# Altium schematic sheet 2407_Alimentation.SchDoc
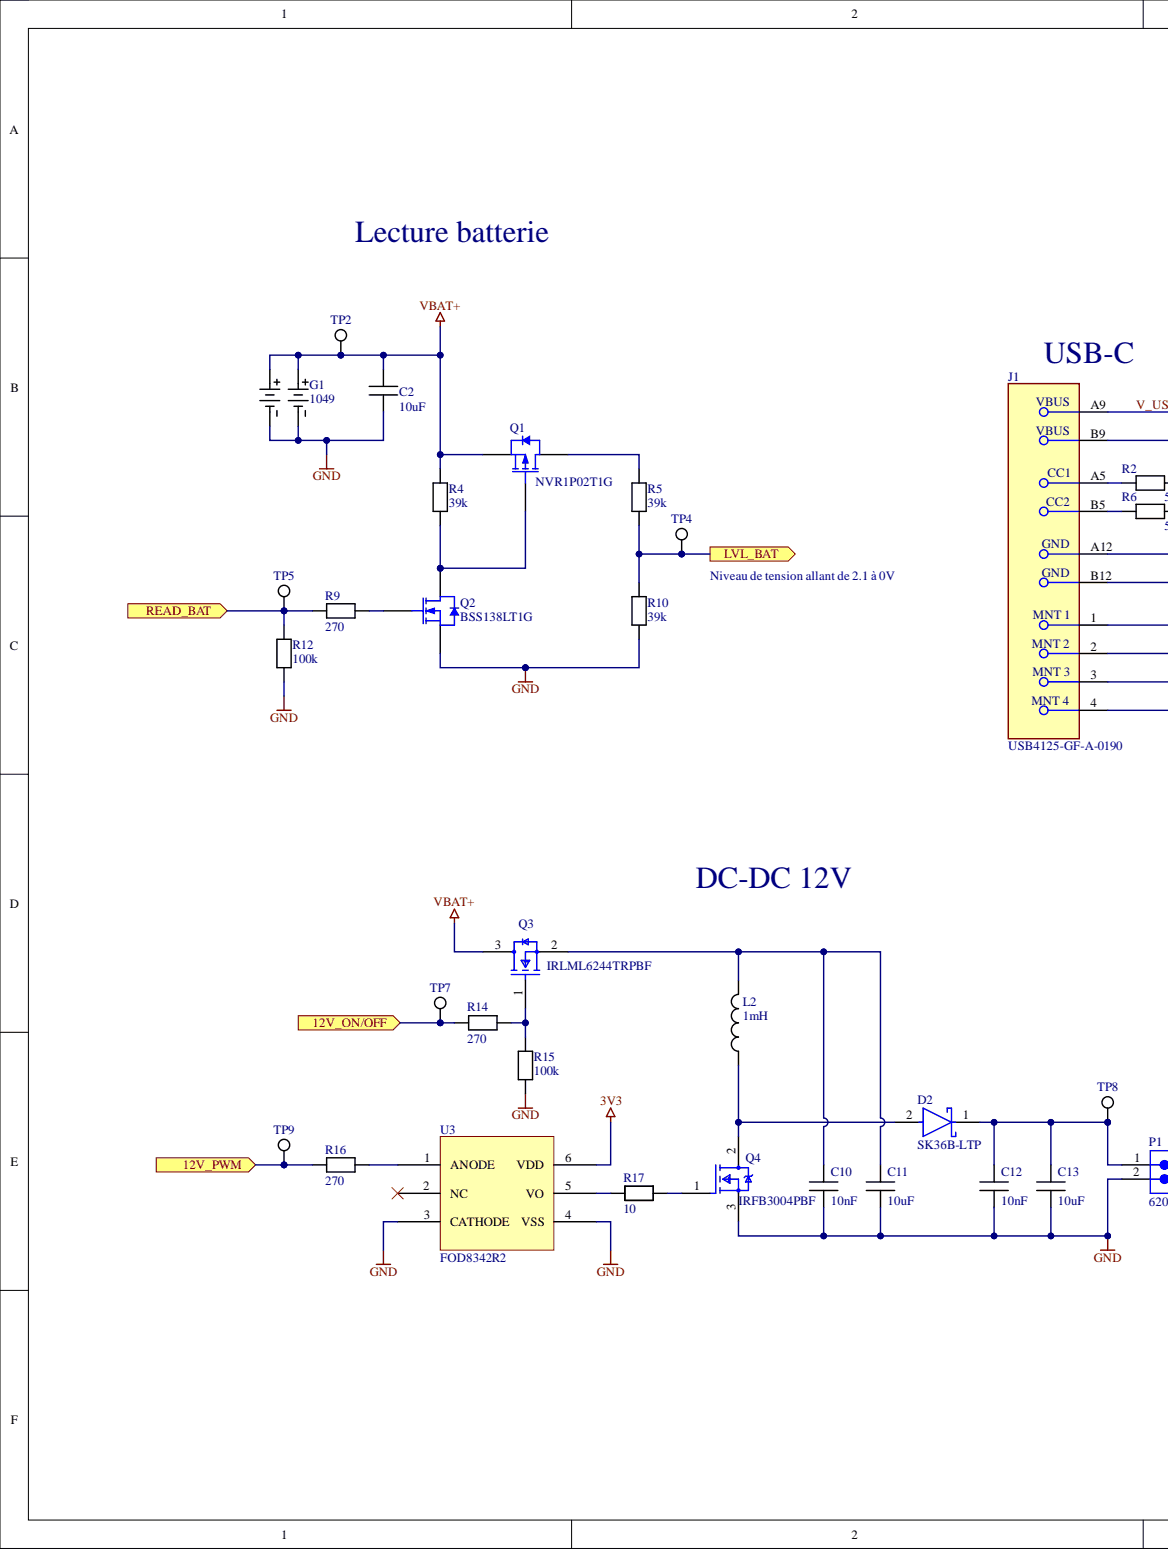
[diagram p0: 2407_Alimentation.SchDoc, left]
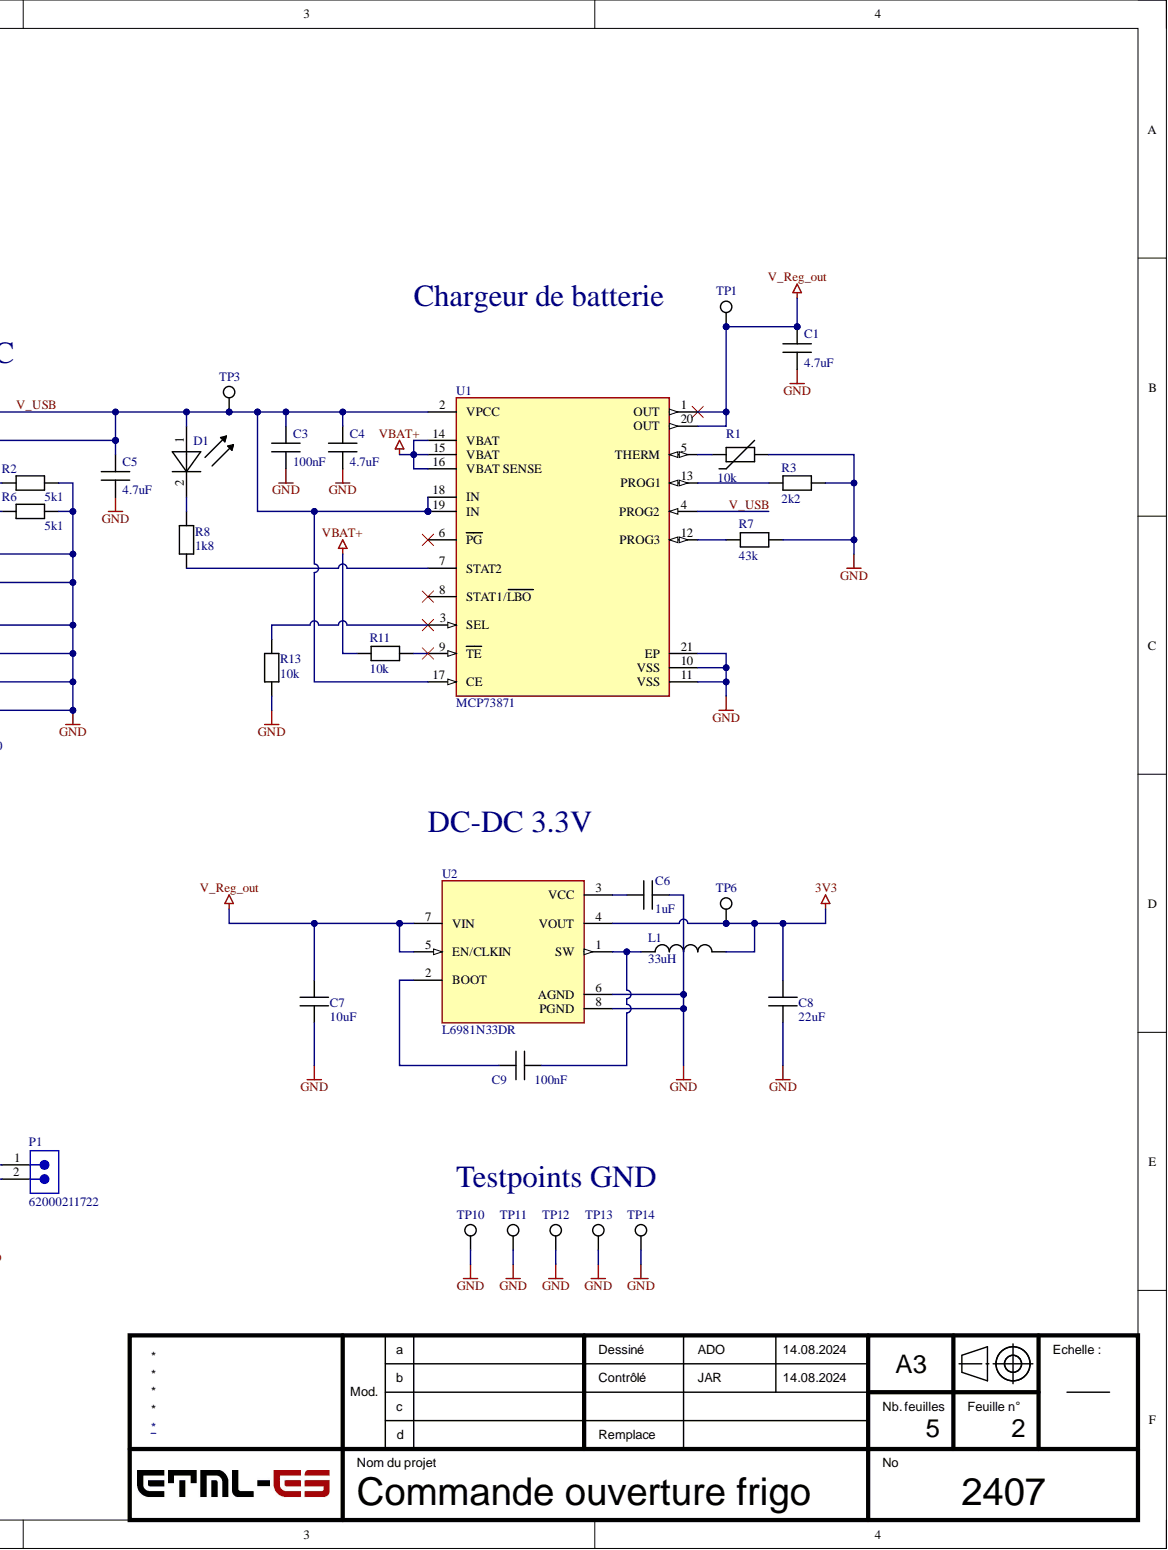
[diagram p1: 2407_Alimentation.SchDoc, right]

## Parts
C1  4.7uF  [CAP0805]
C2  10uF  [CAP0805]
C3  100nF  [CAP0805]
C4  4.7uF  [CAP0805]
C5  4.7uF  [CAP0805]
C6  1uF  [CAP0805]
C7  10uF  [CAP0805]
C8  22uF  [CAP0805]
C9  100nF  [CAP0805]
C10  10nF  [CAP0805]
C11  10uF  [CAP0805]
C12  10nF  [CAP0805]
C13  10uF  [CAP0805]
D1  APTD2012LSURCK  [APTD2012LSURCK]  (value not drawn)
D2  SK36B-LTP  [FP-DO-214AA-MFG]
G1  1049  [1049]
J1  USB4125-GF-A-0190  [FP-USB4125-GF-A-0190-MFG]
L1  33uH  [ASPI-8040S-750M-T]
L2  1mH  [AIRD-02-221K]
P1  62000211722  [62000211722]
Q1  NVR1P02T1G  [ONSC-SOT-23-3-318-08_V]
Q2  BSS138LT1G  [ONSC-SOT-23-3-318-08_V]
Q3  IRLML6244TRPBF  [FP-Micro3-IPC_C]
Q4  IRFB3004PBF  [FP-PG-TO220-3-MFG]
R1  10k  [0805R]
R2  5k1  [0805R]
R3  2k2  [0805R]
R4  39k  [0805R]
R5  39k  [0805R]
R6  5k1  [0805R]
R7  43k  [0805R]
R8  1k8  [0805R]
R9  270  [0805R]
R10  39k  [0805R]
R11  10k  [0805R]
R12  100k  [0805R]
R13  10k  [0805R]
R14  270  [0805R]
R15  100k  [0805R]
R16  270  [0805R]
R17  10  [0805R]
TP1  5019  [KSTN-5019_V]  (value not drawn)
TP2  5019  [KSTN-5019_V]  (value not drawn)
TP3  5019  [KSTN-5019_V]  (value not drawn)
TP4  5019  [KSTN-5019_V]  (value not drawn)
TP5  5019  [KSTN-5019_V]  (value not drawn)
TP6  5019  [KSTN-5019_V]  (value not drawn)
TP7  5019  [KSTN-5019_V]  (value not drawn)
TP8  5019  [KSTN-5019_V]  (value not drawn)
TP9  5019  [KSTN-5019_V]  (value not drawn)
TP10  5019  [KSTN-5019_V]  (value not drawn)
TP11  5019  [KSTN-5019_V]  (value not drawn)
TP12  5019  [KSTN-5019_V]  (value not drawn)
TP13  5019  [KSTN-5019_V]  (value not drawn)
TP14  5019  [KSTN-5019_V]  (value not drawn)
U1  MCP73871  [MCP73871]
U2  L6981N33DR  [L6981N33DR]
U3  FOD8342R2  [FOD8342R2]

## Ports
12V_ON/OFF, 12V_PWM, LVL_BAT, READ_BAT

## Nets
3V3: C8.2 L1.2 TP6.1 U2.4(VOUT) U3.6(VDD)
GND: C1.1 C2.1 C3.1 C4.1 C5.1 C6.1 C7.1 C8.1 C10.1 C11.1 C12.1 C13.1 G1.N1 G1.N2 J1.1 J1.2 J1.3 J1.4 J1.A12 J1.B12 P1.2 Q2.2 Q4.3 R1.2 R2.1 R3.2 R6.1 R7.2 R10.1 R12.1 R13.1 R15.1 TP10.1 TP11.1 TP12.1 TP13.1 TP14.1 U1.10(VSS) U1.11(VSS) U1.21(EP) U2.6(AGND) U2.8(PGND) U3.3(CATHODE) U3.4(VSS)
V_Reg_out: C1.2 C7.2 TP1.1 U1.1(OUT) U1.20(OUT) U2.5(EN/CLKIN) U2.7(VIN)
VBAT+: C2.2 G1.P1 G1.P2 Q1.2 Q3.3 R4.2 R11.2 TP2.1 U1.14(VBAT) U1.15(VBAT) U1.16(VBAT_SENSE)
12V_ON/OFF: R14.1 TP7.1 ; port 12V_ON/OFF
12V_PWM: R16.1 TP9.1 ; port 12V_PWM
LVL_BAT: R5.1 R10.2 TP4.1 ; port LVL_BAT
READ_BAT: R9.1 R12.2 TP5.1 ; port READ_BAT
V_USB: C3.2 C4.2 C5.2 D1.1 J1.A9 J1.B9 TP3.1 U1.2(VPCC) U1.4(PROG2) U1.17(CE) U1.18(IN) U1.19(IN)
NetC6_2: C6.2 U2.3(VCC)
NetC9_1: C9.1 U2.2(BOOT)
NetC9_2: C9.2 L1.1 U2.1(SW)
NetC10_2: C10.2 C11.2 L2.2 Q3.2
NetC12_2: C12.2 C13.2 D2.1 P1.1 TP8.1
NetD1_2: D1.2 R8.2
NetD2_2: D2.2 L2.1 Q4.2
NetJ1_A5: J1.A5 R2.2
NetJ1_B5: J1.B5 R6.2
NetQ1_1: Q1.1 Q2.3 R4.1
NetQ1_3: Q1.3 R5.2
NetQ2_1: Q2.1 R9.2
NetQ3_1: Q3.1 R14.2 R15.2
NetQ4_1: Q4.1 R17.2
NetR1_1: R1.1 U1.5(THERM)
NetR3_1: R3.1 U1.13(PROG1)
NetR7_1: R7.1 U1.12(PROG3)
NetR8_1: R8.1 U1.7(STAT2)
NetR11_1: R11.1 U1.9(TE)
NetR13_2: R13.2 U1.3(SEL)
NetR16_2: R16.2 U3.1(ANODE)
NetR17_1: R17.1 U3.5(VO)

Not connected: U1 6(PG) 8(STAT1/LBO); U3 2(NC)

## Library data of the parts
D2 = CMP-56206-000011-1: DIODE SCHOTTKY 60V 3A DO214AA; Capacitance: 45pF; Element Configuration: Single; Forward Voltage: 750mV; Max Forward Surge Current (Ifsm): 100A; Max Reverse Current: 20mA; Max Reverse Leakage Current: 500uA; Polarity: Standard; Average Rectified Current: 3A; Case/Package: DO-214AA; Component Kind: Standard; Current Rating: 3A; Forward Current: 3A; Height: 2.44mm; Lead Free: Lead Free; Length: 4.57mm; Library Name: Altium Content Vault; Max Operating Temperature: 125°C; Max Repetitive Reverse Voltage (Vrrm): 60V; Max Reverse Voltage (DC): 60V; Max Surge Current: 100A; Min Operating Temperature: -55°C; Mount: Surface Mount; Number of Pins: 2; Output Current: 3A; Package Quantity: 1; Packaging: Tape and Reel; Peak Non-Repetitive Surge Current: 100A; Radiation Hardening: No; RoHS Compliant: Yes; Voltage Rating (DC): 60V; Width: 3.94mm
J1 = CMP-245163-000004-1: CONN RCPT TYPE C 6P SMD RA; Component Kind: Standard; Library Name: Altium Content Vault
L1 = ASPI-4030S-330M-T: Component Kind: Standard; Library Name: 2407_CommandeOuvertureFrigo.SchLib
P1 = CMP-1502-00651-2: NexarPartId: 116664068; Current Rating: 2A; Gender: Male; Insulation Resistance: 1GΩ; Pitch: 2mm; Component Kind: Standard; Contact Plating: Tin; Length: 6mm; Library Name: Altium Content Vault; Max Operating Temperature: 85°C; Min Operating Temperature: -25°C; Number of Pins: 2
Q1 = CMP-1058-00745-1: Power MOSFET, -20 V, -1 A, P-Channel, 3-Pin SOT-23, Pb-Free, Tape and Reel; Manufacturer: On Semiconductor; Channel Polarity: P Channel; Ciss Typ (pF): 165; Configuration: Single; Coss Typ (pF): 110; Crss Typ (pF): 35; ID Max (A): 1; PD Max (W): 0.4; Qrr Typ (nC): 8; V(BR)DSS Min (V): 20; VGS(th) Max (V): 2.3; Component Kind: Standard; ComponentLink1Description: Manufacturer URL; ComponentLink1URL: http://www.onsemi.com/; ComponentLink2Description: Datasheet; ComponentLink2URL: http://www.onsemi.cn/pub_link/Collateral/NTR1P02T1-D.PDF; ComponentLink3Description: Package Specification; ComponentLink3URL: http://www.onsemi.cn/pub_link/Collateral/318-08.PDF; DatasheetVersion: Rev. 7; Library Name: Altium Content Vault; Mounting Technology: Surface Mount; Package Type: SOT-23-3; PackageDescription: 3-Pin Small Outline Transistor, Body 2.92 x 1.3 mm, Pitch 0.95 mm; PackageReference: SOT-23-3-318-08; PackageVersion: Rev. AP, 11/2009; Packing: Tape and Reel; PartNumber: NVR1P02T1G; RoHS: Pb-Free; VGS Max (V): 20
Q2 = CMP-1058-00767-1: Power MOSFET, 200 mA, 50 V, N-Channel, 3-Pin SOT-23, Pb-Free, Tape and Reel; Manufacturer: On Semiconductor; Channel Polarity: N Channel; Ciss Typ (pF): 40; Configuration: Single; Coss Typ (pF): 12; Crss Typ (pF): 3.5; ID Max (A): 0.2; PD Max (W): 0.225; V(BR)DSS Min (V): 50; VGS Max (V): 20; VGS(th) Max (V): 1.5; Component Kind: Standard; ComponentLink1Description: Manufacturer URL; ComponentLink1URL: http://www.onsemi.com/; ComponentLink2Description: Datasheet; ComponentLink2URL: http://www.onsemi.com/pub_link/Collateral/BSS138LT1-D.PDF; ComponentLink3Description: Package Specification; ComponentLink3URL: http://www.onsemi.cn/pub_link/Collateral/318-08.PDF; DatasheetVersion: Rev. 8; Library Name: Altium Content Vault; Mounting Technology: Surface Mount; Package Type: SOT-23-3; PackageDescription: 3-Pin Small Outline Transistor, Body 2.92 x 1.3 mm, Pitch 0.95 mm; PackageReference: SOT-23-3-318-08; PackageVersion: Rev. AP, 11/2009; Packing: Tape and Reel; PartNumber: BSS138LT1G; RoHS: Pb-Free
Q3 = CMP-32901-000185-1: MOSFET N-CH 20V 6.3A SOT-23; Input Capacitance: 700pF; Max Power Dissipation: 1.3W; Rds On Max: 21mR; Threshold Voltage: 900mV; Case/Package: SOT-23; Component Kind: Standard; Continuous Drain Current (ID): 6.3A; Drain to Source Voltage (Vdss): 20V; Halogen Free: Halogen Free; Lead Free: Lead Free; Library Name: Altium Content Vault; Max Dual Supply Voltage: 20V; Max Operating Temperature: 150°C; Min Operating Temperature: -55°C; Mount: Surface Mount; Number of Pins: 3; Packaging: Tape and Reel; REACH SVHC: No SVHC
Q4 = CMP-00196-65873797-1: IRFB3004PBF Single N-Channel 40 V 1.75 mOhm 160 nC HEXFET® Power Mosfet - TO-220-3; Continuous Drain Current (ID): 340A; Drain to Source Breakdown Voltage: 40V; Drain to Source Resistance: 1.75mΩ; Fall Time: 130ns; Gate to Source Voltage (Vgs): 20V; Input Capacitance: 9.2nF; Max Junction Temperature (Tj): 175°C; Max Power Dissipation: 380W; Number of Channels: 1; Rise Time: 220ns; Threshold Voltage: 4V; Turn-Off Delay Time: 90ns; Turn-On Delay Time: 23ns; Case/Package: TO-220AB; Component Kind: Standard; Contact Plating: Tin; Drain to Source Voltage (Vdss): 40V; Element Configuration: Single; Height: 9.017mm; Lead Free: Lead Free; Length: 10.668mm; Library Name: Altium Content Vault; Lifecycle Status: Production; Max Operating Temperature: 175°C; Min Operating Temperature: -55°C; Mount: Through Hole; NexarPartId: 65873797; Number of Elements: 1; Number of Pins: 3; On-State Resistance: 1.75mΩ; Package Quantity: 1000; Power Dissipation: 380W; Radiation Hardening: No; Rds On Max: 1.75mΩ; REACH SVHC: No SVHC; Resistance: 1.75MΩ; RoHS: Compliant; Schedule B: 8541290080, 8541290080; Width: 4.826mm
R1 = NCP21XV103J03RA: Component Kind: Standard; Library Name: 2407_CommandeOuvertureFrigo.SchLib
R2 R6 = 5k1R: Component Kind: Standard; Library Name: 2407_CommandeOuvertureFrigo.SchLib
R3 = 2k2R: Component Kind: Standard; Library Name: 2407_CommandeOuvertureFrigo.SchLib
R4 R5 R10 = 39kR: Component Kind: Standard; Library Name: 2407_CommandeOuvertureFrigo.SchLib
R7 = 43kR: Component Kind: Standard; Library Name: 2407_CommandeOuvertureFrigo.SchLib
R8 = 1k8R: Component Kind: Standard; Library Name: 2407_CommandeOuvertureFrigo.SchLib
R9 R14 R16 = 270R: Component Kind: Standard; Library Name: 2407_CommandeOuvertureFrigo.SchLib
R11 R13 = 10kR: Component Kind: Standard; Library Name: 2407_CommandeOuvertureFrigo.SchLib
R17 = 10R: Component Kind: Standard; Library Name: 2407_CommandeOuvertureFrigo.SchLib
TP1-TP14 = CMP-1672-00008-1: Test Point, 1 Position SMD, RoHS, Tape and Reel; Component Kind: Standard; ComponentLink1Description: Manufacturer URL; ComponentLink1URL: http://www.keyelco.com/; ComponentLink2Description: Datasheet; ComponentLink2URL: http://www.keyelco.com/product-pdf.cfm?p=1357; Contact Plating: Silver; DatasheetVersion: 03/2014; Library Name: Altium Content Vault; Mount: Surface Mount; Mounting Technology: Surface Mount; Package Quantity: 1000; PackageDescription: 1-Pin Surface Mount Device, Body 3.81 x 2.03 mm; PackageReference: 5019; Packaging: Tape and Reel; Pins: 1; RoHSCompliant: True
U1 = MCO73871: Component Kind: Standard; Library Name: Schema relais reed.SchLib
C1 C4 C5 = 4.7uF: Component Kind: Standard; Library Name: 2407_CommandeOuvertureFrigo.SchLib
C2 C7 C11 C13 = 10uF: Component Kind: Standard; Library Name: 2407_CommandeOuvertureFrigo.SchLib
C3 C9 = 100nF: Component Kind: Standard; Library Name: 2407_CommandeOuvertureFrigo.SchLib
C6 = 1uF: Component Kind: Standard; Library Name: 2407_CommandeOuvertureFrigo.SchLib
C8 = 22uF: Component Kind: Standard; Library Name: 2407_CommandeOuvertureFrigo.SchLib
C10 C12 = 10nF: Component Kind: Standard; Library Name: 2407_CommandeOuvertureFrigo.SchLib
D1 = APTD2012LSURCK: Component Kind: Standard; Library Name: 2407_CommandeOuvertureFrigo.SchLib
G1 = 1049: Component Kind: Standard; Library Name: 2407_CommandeOuvertureFrigo.SchLib
L2 = 1mH: Component Kind: Standard; Library Name: 2407_CommandeOuvertureFrigo.SchLib
R12 R15 = 100k: Component Kind: Standard; Library Name: 2407_CommandeOuvertureFrigo.SchLib
U2 = L6981N33DR: Component Kind: Standard; Library Name: 2407_CommandeOuvertureFrigo.SchLib
U3 = FOD8342R2: Component Kind: Standard; Library Name: 2407_CommandeOuvertureFrigo.SchLib
